# Altium schematic sheet overview.SchDoc(overview)
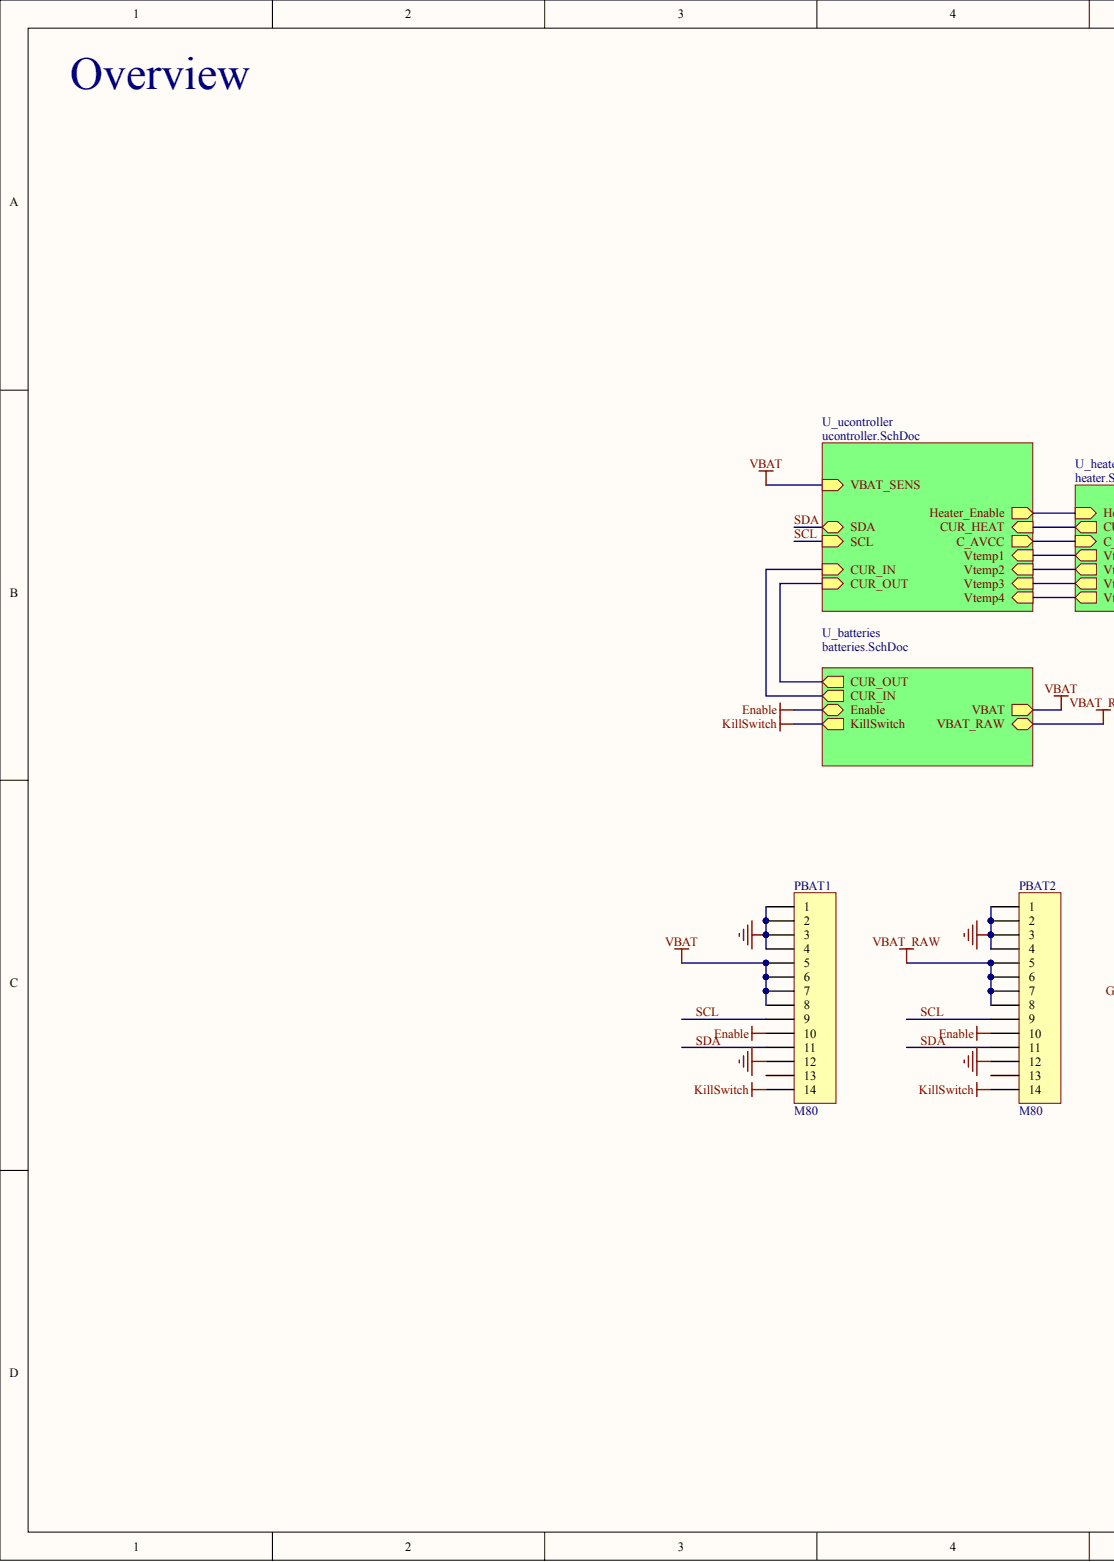
[diagram p0: overview.SchDoc, left]
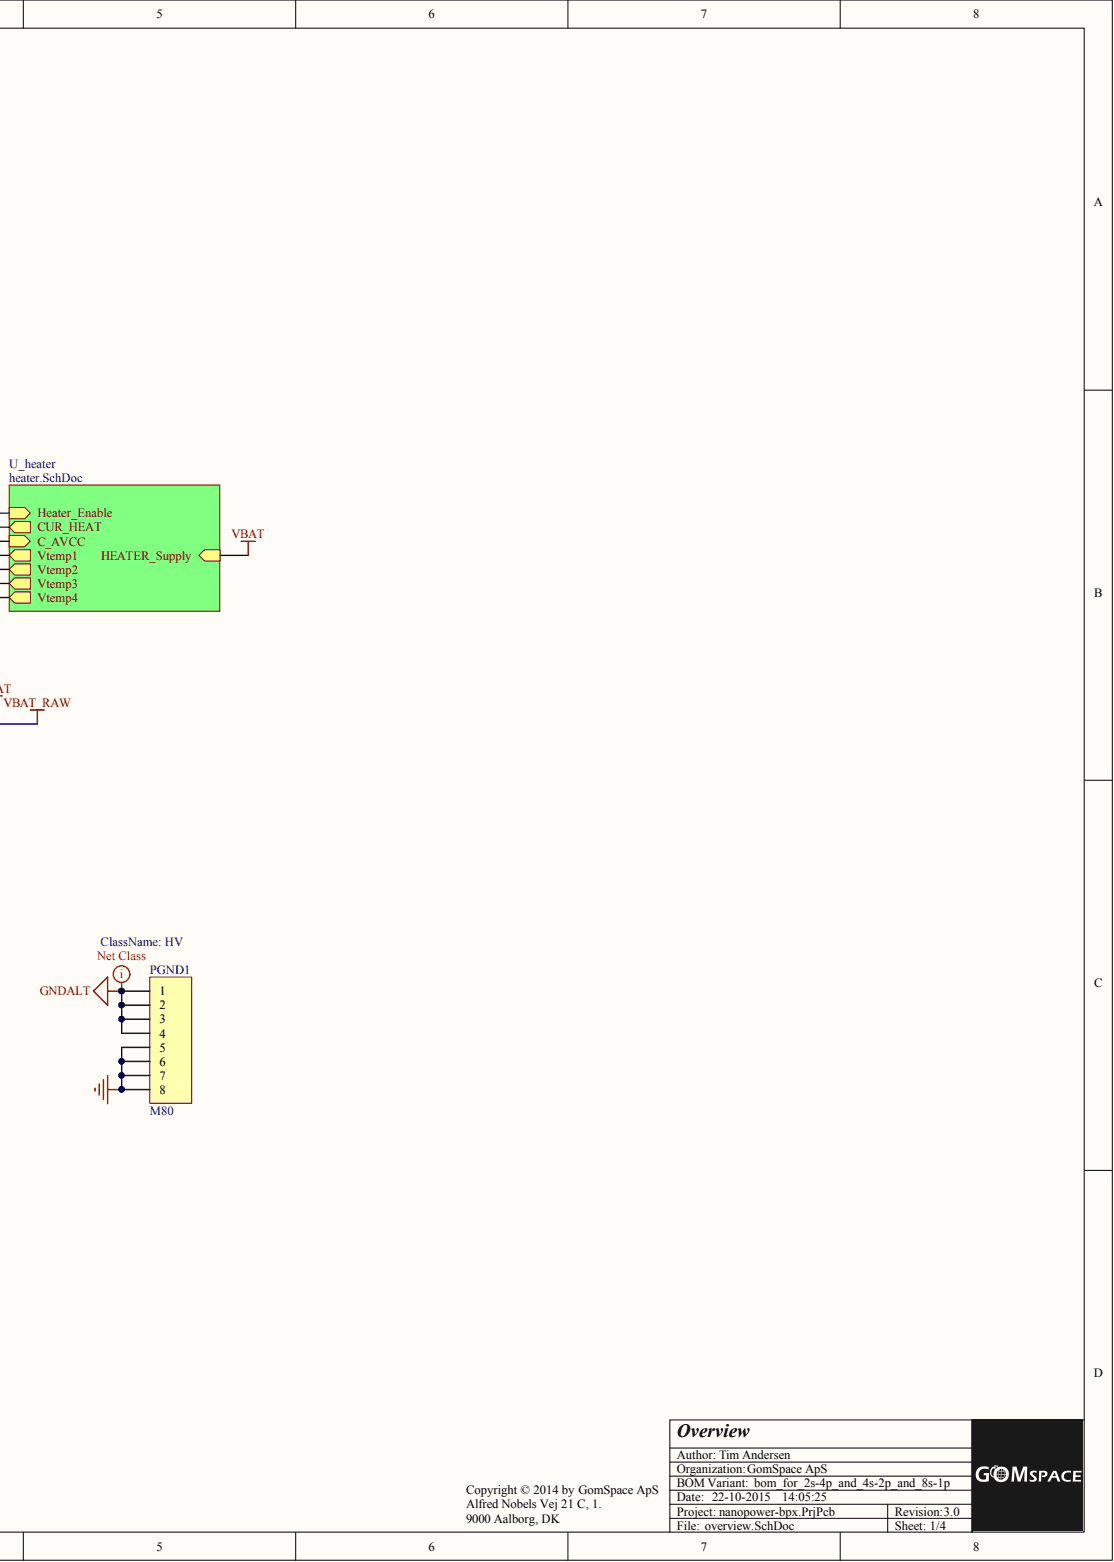
[diagram p1: overview.SchDoc, right]

## Parts
PBAT1  M80  [M80-5421442]
PBAT2  M80  [M80-5421442]
PGND1  M80  [M80-5430805]

## Nets
ENABLE: PBAT1.10 PBAT2.10
GND: PBAT1.1 PBAT1.2 PBAT1.3 PBAT1.4 PBAT1.12 PBAT2.1 PBAT2.2 PBAT2.3 PBAT2.4 PBAT2.12 PGND1.5 PGND1.6 PGND1.7 PGND1.8
GNDALT: PGND1.1 PGND1.2 PGND1.3 PGND1.4
KILLSWITCH: PBAT1.14 PBAT2.14
VBAT: PBAT1.5 PBAT1.6 PBAT1.7 PBAT1.8
VBAT_RAW: PBAT2.5 PBAT2.6 PBAT2.7 PBAT2.8
SCL: PBAT1.9 PBAT2.9
SDA: PBAT1.11 PBAT2.11
NetPBAT1_13: PBAT1.13
NetPBAT2_13: PBAT2.13
C_AVCC:
CUR_HEAT:
CUR_IN:
CUR_OUT:
HEATER_ENABLE:
VTEMP1:
VTEMP2:
VTEMP3:
VTEMP4:

## Library data of the parts
PBAT1 PBAT2 = 12000004: 7+7 WAY M HORIZ BM SMT W/3.5MM; Manufacturer: Harwin; Part_Type: CONNECTOR; Database Table Name: Connectors$; Hight: 6.05mm; Component Kind: Standard; Datasheet: https://cdn.harwin.com/pdfs/M80-542.pdf; LatestRevisionDate: 17-Jul-2002; LatestRevisionNote: Re-released for DXP Platform.; Manufacturer number: M80-5421442; Package: M80-5421442; Part Number: 12000004; Pin Count: 14; ROHS: YES; Supplier 1: Farnell; Supplier 2: Digi-Key; Supplier 3: Mouser; Supplier 4: Gomspace ApS; Supplier Part Number 1: 1.89527e+006; Supplier Part Number 2: M80-5421442-ND; Supplier Part Number 3: 855-M80-5421442; Supplier Part Number 4: 1456; Value: M80; Library Name: GomSpace_Database_Libs.DbLib; Publisher: Altium Limited
PGND1 = 12000009: 4+4 WAY M HORIZ BM SMT W/3.5MM; Manufacturer: Harwin; Part_Type: CONNECTOR; Database Table Name: Connectors$; Hight: 6.05mm; Component Kind: Standard; Datasheet: http://www.mouser.com/ds/2/181/60PAGE58-242110.pdf; LatestRevisionDate: 17-Jul-2002; LatestRevisionNote: Re-released for DXP Platform.; Manufacturer number: M80-5430805; Package: M80-5430805; Part Number: 12000009; Pin Count: 8; ROHS: YES; Supplier 1: AVNET; Supplier 2: mouser; Supplier 4: Gomspace ApS; Supplier Part Number 2: 855-M80-5430805; Value: M80; Library Name: GomSpace_Database_Libs.DbLib; Publisher: Altium Limited
